# Altium schematic sheet MCU.SchDoc
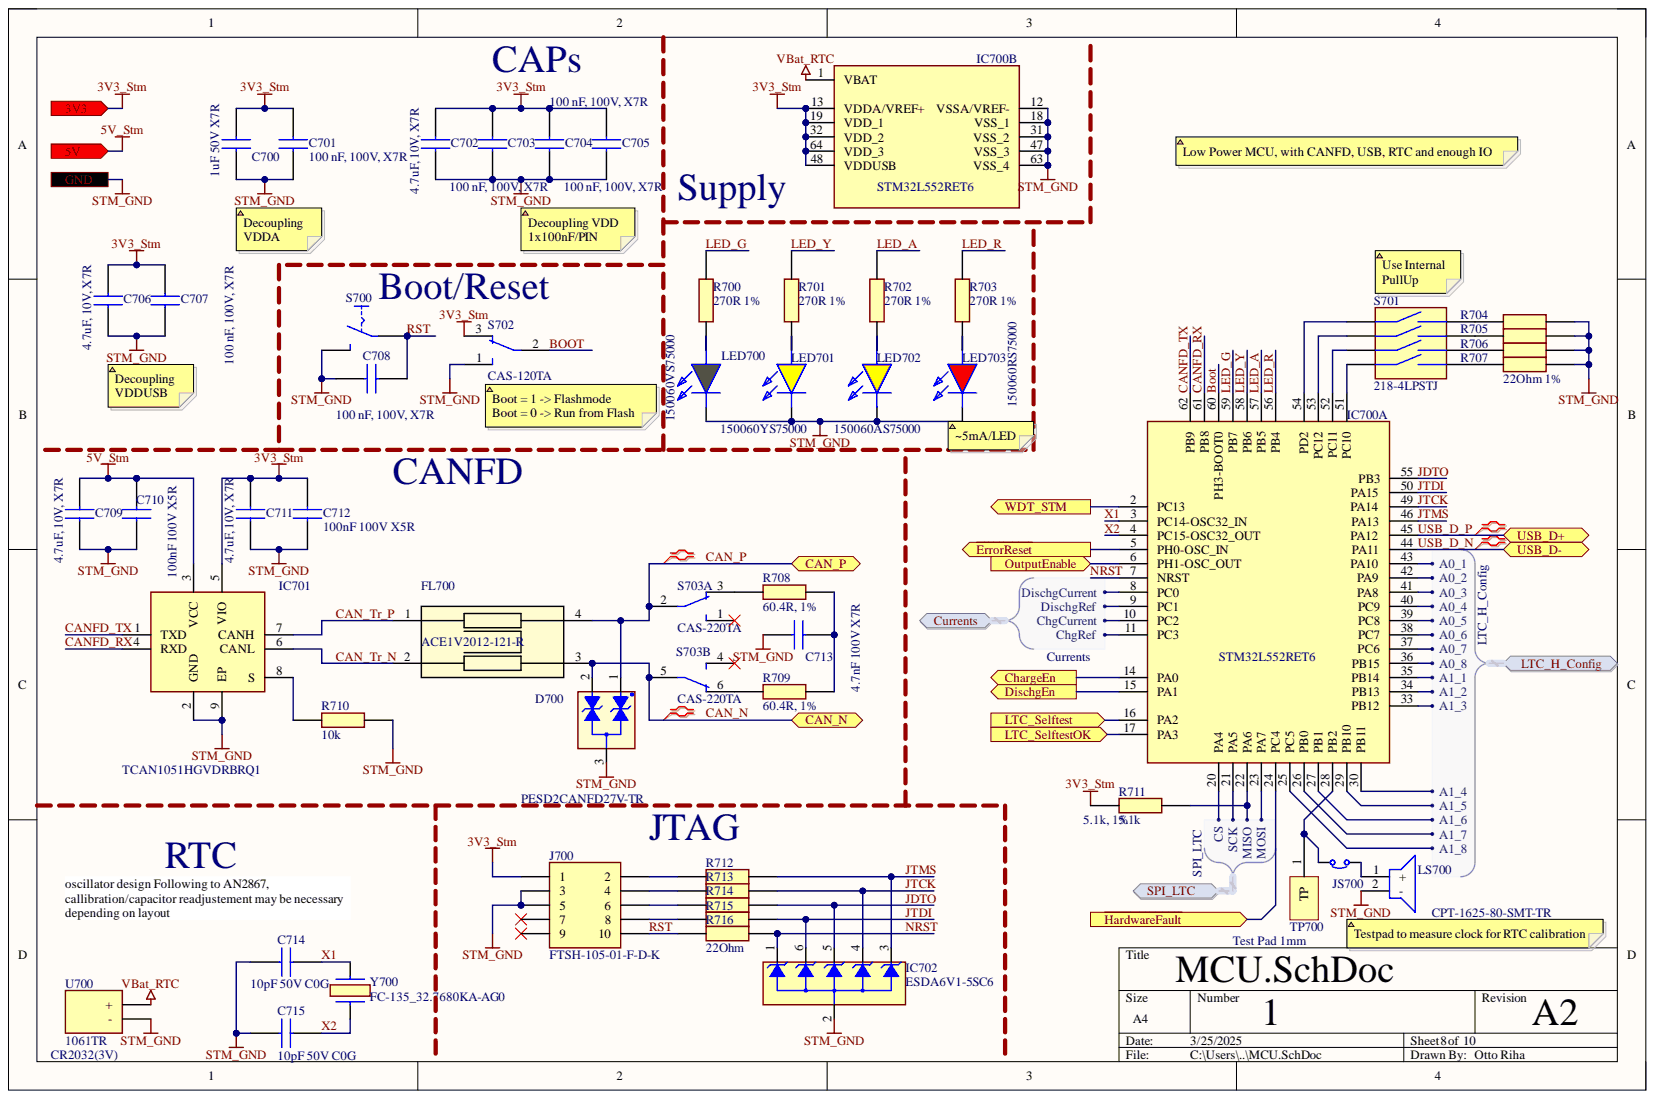
[diagram p0: MCU.SchDoc]

## Parts
C700  1uF 50V X7R  value 1uF  [CAPC1608X90N]
C701  100 nF, 100V, X7R  [MAJx16]
C702  4.7uF, 10V, X7R  [GRM18x]
C703  100 nF, 100V, X7R  [MAJx16]
C704  100 nF, 100V, X7R  [MAJx16]
C705  100 nF, 100V, X7R  [MAJx16]
C706  4.7uF, 10V, X7R  [GRM18x]
C707  100 nF, 100V, X7R  [MAJx16]
C708  100 nF, 100V, X7R  [MAJx16]
C709  4.7uF, 10V, X7R  [GRM18x]
C710  100nF 100V X5R  value 100nF  [CAPC1608X90N]
C711  4.7uF, 10V, X7R  [GRM18x]
C712  100nF 100V X5R  value 100nF  [CAPC1608X90N]
C713  4.7nF 100V X7R  value 4.7nF 100V  [CAPC1608X80N]
C714  10pF 50V C0G  [CAPC1608X90N]
C715  10pF 50V C0G  [CAPC1608X90N]
D700  PESD2CANFD27V-TR  [SOT95P230X110-3N]
FL700  ACE1V2012-121-R  [ACE1V2012121R]
IC700  STM32L552RET6  [QFP50P1200X1200X160-64N]  (parts A,B)
IC701  TCAN1051HGVDRBRQ1  [SON65P300X300X100-9N-D]
IC702  ESDA6V1-5SC6  [SOT95P280X145-6N]
J700  FTSH-105-01-F-D-K  [FTSH-105-XX-YYY-D-K]
JS700  SolderJumper  [SolderJumper]  (value not drawn)
LED700  150060VS75000  [LEDC1608X80N]
LED701  150060YS75000  [LEDC1608X80N]
LED702  150060AS75000  [LEDC1608X80N]
LED703  150060RS75000  [LEDC1608X80N]
LS700  CPT-1625-80-SMT-TR  [CPT162580SMTTR]
R700  270R 1%  value 270R  [RESC1608X55N]
R701  270R 1%  value 270R  [RESC1608X55N]
R702  270R 1%  value 270R  [RESC1608X55N]
R703  270R 1%  value 270R  [RESC1608X55N]
R704  value 22Ohm  [RESC1608X55N]  (hidden comment: 22Ohm 1%)
R705  value 22Ohm  [RESC1608X55N]  (hidden comment: 22Ohm 1%)
R706  22Ohm 1%  value 22Ohm  [RESC1608X55N]
R707  22Ohm 1%  [RESC1608X55N]
R708  60.4R, 1%  [RESC1608X55N]
R709  60.4R, 1%  [RESC1608X55N]
R710  value 10k  [RESC1608X50N]  (hidden comment: CRCW060310K0FKEI)
R711  5.1k, 1%  value 5.1k  [RESC1608X55N]
R712  value 22Ohm  [RESC1608X55N]  (hidden comment: 22Ohm 1%)
R713  value 22Ohm  [RESC1608X55N]  (hidden comment: 22Ohm 1%)
R714  value 22Ohm  [RESC1608X55N]  (hidden comment: 22Ohm 1%)
R715  22Ohm 1%  value 22Ohm  [RESC1608X55N]
R716  22Ohm 1%  value 22Ohm  [RESC1608X55N]
S700  MJTP1140A  [MJTP1140A]  (value not drawn)
S701  218-4LPSTJ  [2184LPSTJ]
S702  CAS-120TA  [CAS120TA]
S703  CAS-220TA  [CAS220TA]  (parts A,B)
TP700  Test Pad 1mm  [Test Pad 1mm-Footprint-1]
U700  1061TR  [1061TR]
Y700  FC-135_32.7680KA-AG0  [FC135327680KAAG0]

## Ports
3V3, 5V, CAN_N, CAN_P, ChargeEn, Currents, Currents.ChgCurrent, Currents.ChgRef, Currents.DischgCurrent, Currents.DischgRef, DischgEn, GND, LTC_H_Config, LTC_H_Config.A0_1, LTC_H_Config.A0_2, LTC_H_Config.A0_3, LTC_H_Config.A0_4, LTC_H_Config.A0_5, LTC_H_Config.A0_6, LTC_H_Config.A0_7, LTC_H_Config.A0_8, LTC_H_Config.A1_1, LTC_H_Config.A1_2, LTC_H_Config.A1_3, LTC_H_Config.A1_4, LTC_H_Config.A1_5, LTC_H_Config.A1_6, LTC_H_Config.A1_7, LTC_H_Config.A1_8, LTC_Selftest, LTC_SelftestOK, OutputEnable, SPI_LTC, SPI_LTC.CS, SPI_LTC.MISO, SPI_LTC.MOSI, SPI_LTC.SCK, USB_D+, USB_D-, WDT_STM, ~{ErrorReset}, ~{HardwareFault}

## Nets
VBat_RTC: IC700.1(VBAT) U700.1
3V3_Stm: C700.2 C701.2 C702.2 C703.2 C704.2 C705.2 C706.2 C707.2 C711.2 C712.2 IC700.13(VDDA/VREF+) IC700.19(VDD_1) IC700.32(VDD_2) IC700.48(VDDUSB) IC700.64(VDD_3) IC701.5(VIO) J700.1 R711.1 S702.3 ; port 3V3
5V_Stm: C709.2 C710.2 IC701.3(VCC) ; port 5V
Boot: IC700.60(PH3-BOOT0) S702.2
CAN_N: D700.2 FL700.3 S703.5 ; port CAN_N
CAN_P: D700.1 FL700.4 S703.2 ; port CAN_P
CAN_Tr_N: FL700.2 IC701.6(CANL)
CAN_Tr_P: FL700.1 IC701.7(CANH)
CANFD_RX: IC700.61(PB8) IC701.4(RXD)
CANFD_TX: IC700.62(PB9) IC701.1(TXD)
ChargeEn: IC700.14(PA0) ; port ChargeEn
DischgEn: IC700.15(PA1) ; port DischgEn
~{ErrorReset}: IC700.5(PH0-OSC_IN) ; port ~{ErrorReset}
~{HardwareFault}: IC700.24(PC4) ; port ~{HardwareFault}
JDTO: IC700.55(PB3) IC702.5 R714.2
JTCK: IC700.49(PA14) IC702.4 R713.2
JTDI: IC700.50(PA15) IC702.6 R715.2
JTMS: IC700.46(PA13) IC702.3 R712.2
LED_A: IC700.57(PB5) R702.2
LED_G: IC700.59(PB7) R700.2
LED_R: IC700.56(PB4) R703.2
LED_Y: IC700.58(PB6) R701.2
LTC_Selftest: IC700.16(PA2) ; port LTC_Selftest
LTC_SelftestOK: IC700.17(PA3) ; port LTC_SelftestOK
NRST: IC700.7(NRST) IC702.1 R716.2
OutputEnable: IC700.6(PH1-OSC_OUT) ; port OutputEnable
RST: C708.1 J700.10 R716.1 S700.1
STM_GND: C700.1 C701.1 C702.1 C703.1 C704.1 C705.1 C706.1 C707.1 C708.2 C709.1 C710.1 C711.1 C712.1 C713.1 C714.2 C715.2 D700.3 IC700.12(VSSA/VREF-) IC700.18(VSS_1) IC700.31(VSS_2) IC700.47(VSS_3) IC700.63(VSS_4) IC701.2(GND) IC701.9(EP) IC702.2 J700.3 J700.5 LED700.1 LED701.1 LED702.1 LED703.1 LS700.2 R704.1 R705.1 R706.1 R707.1 R710.1 S700.2 S702.1 U700.2 ; port GND
USB_D_N: IC700.44(PA11)
USB_D_P: IC700.45(PA12)
WDT_STM: IC700.2(PC13) ; port WDT_STM
X1: C714.1 IC700.3(PC14-OSC32_IN) Y700.1
X2: C715.1 IC700.4(PC15-OSC32_OUT) Y700.2
NetC713_2: C713.2 R708.2 R709.1
NetIC700_8: IC700.8(PC0) ; port Currents
NetIC700_9: IC700.9(PC1) ; port Currents
NetIC700_10: IC700.10(PC2) ; port Currents
NetIC700_11: IC700.11(PC3) ; port Currents
NetIC700_20: IC700.20(PA4) ; port SPI_LTC
NetIC700_21: IC700.21(PA5) ; port SPI_LTC
NetIC700_22: IC700.22(PA6) R711.2 ; port SPI_LTC
NetIC700_23: IC700.23(PA7) ; port SPI_LTC
NetIC700_25: IC700.25(PC5) ; port LTC_H_Config
NetIC700_26: IC700.26(PB0) ; port LTC_H_Config
NetIC700_27: IC700.27(PB1) ; port LTC_H_Config
NetIC700_28: IC700.28(PB2) JS700.1 TP700.1
NetIC700_29: IC700.29(PB10) ; port LTC_H_Config
NetIC700_30: IC700.30(PB11) ; port LTC_H_Config
NetIC700_33: IC700.33(PB12) ; port LTC_H_Config
NetIC700_34: IC700.34(PB13) ; port LTC_H_Config
NetIC700_35: IC700.35(PB14) ; port LTC_H_Config
NetIC700_36: IC700.36(PB15) ; port LTC_H_Config
NetIC700_37: IC700.37(PC6) ; port LTC_H_Config
NetIC700_38: IC700.38(PC7) ; port LTC_H_Config
NetIC700_39: IC700.39(PC8) ; port LTC_H_Config
NetIC700_40: IC700.40(PC9) ; port LTC_H_Config
NetIC700_41: IC700.41(PA8) ; port LTC_H_Config
NetIC700_42: IC700.42(PA9) ; port LTC_H_Config
NetIC700_43: IC700.43(PA10) ; port LTC_H_Config
NetIC700_51: IC700.51(PC10) S701.B4
NetIC700_52: IC700.52(PC11) S701.B3
NetIC700_53: IC700.53(PC12) S701.B2
NetIC700_54: IC700.54(PD2) S701.B1
NetIC701_8: IC701.8(S) R710.2
NetJ700_2: J700.2 R712.1
NetJ700_4: J700.4 R713.1
NetJ700_6: J700.6 R714.1
NetJ700_7: J700.7
NetJ700_8: J700.8 R715.1
NetJ700_9: J700.9
NetJS700_2: JS700.2 LS700.1
NetLED700_2: LED700.2 R700.1
NetLED701_2: LED701.2 R701.1
NetLED702_2: LED702.2 R702.1
NetLED703_2: LED703.2 R703.1
NetR704_2: R704.2 S701.A1
NetR705_2: R705.2 S701.A2
NetR706_2: R706.2 S701.A3
NetR707_2: R707.2 S701.A4
NetR708_1: R708.1 S703.3
NetR709_2: R709.2 S703.6
NetS703_1: S703.1
NetS703_4: S703.4

## Library data of the parts
C700 = 06035C105MAT2A: Capacitor 1uF 50V X7R; Manufacturer_Name: Kyocera AVX; Component Kind: Standard; Datasheet Link: https://componentsearchengine.com/Datasheets/1/06031A100FAT2A.pdf; Height: 0.9mm; Library Name: SamacSys.SchLib; Manufacturer_Part_Number: 06035C105MAT2A; Mouser Part Number: 581-06035C105MAT2A; Mouser Price/Stock: https://www.mouser.co.uk/ProductDetail/KYOCERA-AVX/06035C105MAT2A?qs=%252BdQmOuGyFcHMsevPtc6OZQ%3D%3D
C701 C703-C705 C707 C708 = MAJCH168BB7104KTEA01: Capacitor 100nF, 100V, X7R; Manufacturer_Name: TAIYO YUDEN; Arrow Part Number: MAJCH168BB7104KTEA01; Arrow Price/Stock: https://www.arrow.com/en/products/majch168bb7104ktea01/taiyo-yuden?utm_currency=USD&region=nac; Component Kind: Standard; Datasheet Link: https://ds.yuden.co.jp/TYCOMPAS/or/detail?pn=MAJCH168BB7104KTEA01; Height: 0.8mm; Library Name: SamacSys.SchLib; Manufacturer_Part_Number: MAJCH168BB7104KTEA01; Mouser Part Number: 963-MAJCH168BB7104KT; Mouser Price/Stock: https://www.mouser.co.uk/ProductDetail/TAIYO-YUDEN/MAJCH168BB7104KTEA01?qs=ZcfC38r4PovaTBrG0IdezQ%3D%3D; Supplier 1: Mouser; Supplier Part Number 1: 963-MAJCH168BB7104KT
C702 C706 C709 C711 = GRM188Z71A475KE15J: Capacitor  4.7uF, 10V, X7R; Manufacturer_Name: Murata Electronics; Component Kind: Standard; Datasheet Link: https://search.murata.co.jp/Ceramy/image/img/A01X/G101/ENG/GRM188Z71A475KE15-01A.pdf; Height: 0.9mm; Library Name: SamacSys.SchLib; Manufacturer_Part_Number: GRM188Z71A475KE15J; Mouser Part Number: 81-GRM188Z71A475KE5J; Mouser Price/Stock: https://www.mouser.co.uk/ProductDetail/Murata-Electronics/GRM188Z71A475KE15J?qs=Ey7%2FXF42M3fe52ZB0l7gLw%3D%3D; Supplier 1: Mouser; Supplier Part Number 1: 81-GRM188Z71A475KE5J
C710 C712 = HMK107BJ104KA-T: Capacitor 100nF X5R 100VDC; Manufacturer_Name: TAIYO YUDEN; Component Kind: Standard; Datasheet Link: https://ds.yuden.co.jp/TYCOMPAS/jp/specSheet?pn=MSASH168SB5104KTNA01; Height: 0.9mm; Library Name: SamacSys.SchLib; Manufacturer_Part_Number: HMK107BJ104KA-T; Mouser Part Number: 963-HMK107BJ104KA-T; Mouser Price/Stock: https://www.mouser.co.uk/ProductDetail/Taiyo-Yuden/HMK107BJ104KA-T?qs=I6KAKw0tg2wpEwZmo3gKZg%3D%3D
  C710: Value: 100nF
C713 = C1608X7R2A472K080AA: Capacitor 4.7nF 100V X7R; Manufacturer_Name: TDK; Arrow Part Number: C1608X7R2A472K080AA; Arrow Price/Stock: https://www.arrow.com/en/products/c1608x7r2a472k080aa/tdk?utm_currency=USD&region=nac; Component Kind: Standard; Datasheet Link: https://product.tdk.com/info/en/catalog/datasheets/mlcc_commercial_midvoltage_en.pdf; Height: 0.8mm; Library Name: SamacSys.SchLib; Manufacturer_Part_Number: C1608X7R2A472K080AA; Mouser Part Number: 810-C1608X7R2A472K; Mouser Price/Stock: https://www.mouser.co.uk/ProductDetail/TDK/C1608X7R2A472K080AA/?qs=NRhsANhppD8eiVaujK4TFA%3D%3D; Value: 4.7nF 100V
C714 C715 = 06035A100BAT2A: Capacitor 10pF 50V C0G; Manufacturer_Name: Kyocera AVX; Component Kind: Standard; Datasheet Link: https://componentsearchengine.com/Datasheets/1/06031A100FAT2A.pdf; Height: 0.9mm; Library Name: SamacSys.SchLib; Manufacturer_Part_Number: 06035A100BAT2A; Mouser Part Number: 581-06035A100BAT2A; Mouser Price/Stock: https://www.mouser.com/Search/Refine.aspx?Keyword=581-06035A100BAT2A
D700 = PESD2CANFD27V-TR: Diode; Manufacturer_Name: Nexperia; Arrow Part Number: PESD2CANFD27V-TR; Arrow Price/Stock: null?utm_currency=USD&region=nac; Component Kind: Standard; Datasheet Link: https://assets.nexperia.com/documents/data-sheet/PESD2CANFD27V-T.pdf; Height: 1.1mm; Library Name: SamacSys.SchLib; Manufacturer_Part_Number: PESD2CANFD27V-TR; Mouser Part Number: 771-PESD2CANFD27V-TR; Mouser Price/Stock: https://www.mouser.co.uk/ProductDetail/Nexperia/PESD2CANFD27V-TR?qs=OlC7AqGiEDlVVUGGaoaotA%3D%3D
FL700 = ACE1V2012-121-R: Filter; Manufacturer_Name: Eaton; Component Kind: Standard; Datasheet Link: https://www.eaton.com/content/dam/eaton/products/electronic-components/resources/data-sheet/eaton-ace1v2012-automotive-common-mode-chip-inductor-data-sheet.pdf; Height: 1.4mm; Library Name: SamacSys.SchLib; Manufacturer_Part_Number: ACE1V2012-121-R; Mouser Part Number: 504-ACE1V2012-121-R; Mouser Price/Stock: https://www.mouser.co.uk/ProductDetail/Coiltronics-Eaton/ACE1V2012-121-R?qs=YwPsRIUVAOfYW6FI0EMgkw%3D%3D
IC700 = STM32L552RET6: Integrated Circuit; Manufacturer_Name: STMicroelectronics; Arrow Part Number: STM32L552RET6; Arrow Price/Stock: https://www.arrow.com/en/products/stm32l552ret6/stmicroelectronics?region=nac; Component Kind: Standard; Datasheet Link: https://www.mouser.in/datasheet/2/389/dm00532748-1799512.pdf; Height: 1.6mm; Library Name: SamacSys.SchLib; Manufacturer_Part_Number: STM32L552RET6; Mouser Part Number: 511-STM32L552RET6; Mouser Price/Stock: https://www.mouser.co.uk/ProductDetail/STMicroelectronics/STM32L552RET6?qs=%252B6g0mu59x7I6Ku2G1CuBXA%3D%3D
IC701 = TCAN1051HGVDRBRQ1: Integrated Circuit; Manufacturer_Name: Texas Instruments; Arrow Part Number: TCAN1051HGVDRBRQ1; Arrow Price/Stock: https://www.arrow.com/en/products/tcan1051hgvdrbrq1/texas-instruments?region=nac; Component Kind: Standard; Datasheet Link: http://www.ti.com/lit/gpn/TCAN1051HGV-Q1; Height: 1mm; Library Name: SamacSys.SchLib; Manufacturer_Part_Number: TCAN1051HGVDRBRQ1; Mouser Part Number: 595-CAN1051HGVDRBRQ1; Mouser Price/Stock: https://www.mouser.co.uk/ProductDetail/Texas-Instruments/TCAN1051HGVDRBRQ1?qs=HXFqYaX1Q2xR%252Bfd0ySUCjA%3D%3D
IC702 = ESDA6V1-5SC6: Integrated Circuit; Manufacturer_Name: STMicroelectronics; Arrow Part Number: ESDA6V1-5SC6; Arrow Price/Stock: https://www.arrow.com/en/products/esda6v1-5sc6/stmicroelectronics?region=nac; Component Kind: Standard; Datasheet Link: http://www.st.com/st-web-ui/static/active/en/resource/technical/document/datasheet/CD00005102.pdf; Height: 1.45mm; Library Name: SamacSys.SchLib; Manufacturer_Part_Number: ESDA6V1-5SC6; Mouser Part Number: 511-ESDA6V1-5SC6; Mouser Price/Stock: https://www.mouser.co.uk/ProductDetail/STMicroelectronics/ESDA6V1-5SC6?qs=ZN9SVtODT3yxqNpCUKP6Bg%3D%3D
J700 = FTSH-105-01-F-D-K: Connector; Manufacturer_Name: SAMTEC; Arrow Part Number: FTSH-105-01-F-D-K; Arrow Price/Stock: https://www.arrow.com/en/products/ftsh-105-01-f-d-k/samtec?utm_currency=USD&region=europe; Component Kind: Standard; Datasheet Link: http://suddendocs.samtec.com/prints/ftsh-1xx-xx-xxx-d-xxx-xx-mkt.pdf; Library Name: SamacSys.SchLib; Manufacturer_Part_Number: FTSH-105-01-F-D-K; Mouser Part Number: 200-FTSH10501FDK; Mouser Price/Stock: https://www.mouser.co.uk/ProductDetail/Samtec/FTSH-105-01-F-D-K?qs=FESYatJ8odIwRE%252Bc%2FZcQYA%3D%3D
LED700 = 150060VS75000: LED; Manufacturer_Name: Wurth Elektronik; Component Kind: Standard; Datasheet Link: https://componentsearchengine.com/Datasheets/2/150060VS75000.pdf; Height: 0.8mm; Library Name: SamacSys.SchLib; Manufacturer_Part_Number: 150060VS75000; Mouser Part Number: 710-150060VS75000; Mouser Price/Stock: https://www.mouser.co.uk/ProductDetail/Wurth-Elektronik/150060VS75000?qs=LlUlMxKIyB1Q1Bi5mQ%2FKLw%3D%3D
LED701 = 150060YS75000: LED; Manufacturer_Name: Wurth Elektronik; Component Kind: Standard; Datasheet Link: http://uk.rs-online.com/web/p/products/8154219; Height: 0.8mm; Library Name: SamacSys.SchLib; Manufacturer_Part_Number: 150060YS75000; Mouser Part Number: 710-150060YS75000; Mouser Price/Stock: https://www.mouser.co.uk/ProductDetail/Wurth-Elektronik/150060YS75000?qs=LlUlMxKIyB0nKmwefHgtZw%3D%3D
LED702 = 150060AS75000: LED; Manufacturer_Name: Wurth Elektronik; Component Kind: Standard; Datasheet Link: https://componentsearchengine.com/Datasheets/2/150060AS75000.pdf; Height: 0.8mm; Library Name: SamacSys.SchLib; Manufacturer_Part_Number: 150060AS75000; Mouser Part Number: 710-150060AS75000; Mouser Price/Stock: https://www.mouser.co.uk/ProductDetail/Wurth-Elektronik/150060AS75000?qs=d0WKAl%252BL4KbvMBYryeof0Q%3D%3D
LED703 = 150060RS75000: LED; Manufacturer_Name: Wurth Elektronik; Component Kind: Standard; Datasheet Link: http://katalog.we-online.de/led/datasheet/150060RS75000.pdf; Height: 0.8mm; Library Name: SamacSys.SchLib; Manufacturer_Part_Number: 150060RS75000; Mouser Part Number: 710-150060RS75000; Mouser Price/Stock: https://www.mouser.co.uk/ProductDetail/Wurth-Elektronik/150060RS75000?qs=LlUlMxKIyB3QnmZ3fw%2FVCA%3D%3D
LS700 = CPT-1625-80-SMT-TR: Loudspeaker or Buzzer; Manufacturer_Name: Same Sky; Component Kind: Standard; Datasheet Link: https://www.sameskydevices.com/product/resource/supplyframepdf/cpt-1625-80-smt-tr.pdf; Height: 3mm; Manufacturer_Part_Number: CPT-1625-80-SMT-TR; Mouser Part Number: 490-CPT-1625-80SMTTR; Mouser Price/Stock: https://www.mouser.co.uk/ProductDetail/CUI-Devices/CPT-1625-80-SMT-TR?qs=P1JMDcb91o43eCFIymFs3Q%3D%3D
R700-R703 = ERJ-3EKF2700V: Resistor 270R 1%; Manufacturer_Name: Panasonic; Arrow Part Number: ERJ-3EKF2700V; Arrow Price/Stock: https://www.arrow.com/en/products/erj-3ekf2700v/panasonic?region=nac; Component Kind: Standard; Datasheet Link: http://industrial.panasonic.com/cdbs/www-data/pdf/RDA0000/AOA0000C86.pdf; Height: 0.55mm; Library Name: SamacSys.SchLib; Manufacturer_Part_Number: ERJ-3EKF2700V; Mouser Part Number: 667-ERJ-3EKF2700V; Mouser Price/Stock: https://www.mouser.co.uk/ProductDetail/Panasonic/ERJ-3EKF2700V?qs=iBwFsHVUAOdaUVxng5ojCw%3D%3D
R704-R707 R712-R716 = RC0603FR-0722RL: Resistor 22Ohm 1%; Manufacturer_Name: YAGEO; Arrow Part Number: RC0603FR-0722RL; Arrow Price/Stock: https://www.arrow.com/en/products/rc0603fr-0722rl/yageo?region=nac; Component Kind: Standard; Datasheet Link: http://www.farnell.com/datasheets/1868787.pdf; Height: 0.55mm; Library Name: SamacSys.SchLib; Manufacturer_Part_Number: RC0603FR-0722RL; Mouser Part Number: 603-RC0603FR-0722RL; Mouser Price/Stock: https://www.mouser.co.uk/ProductDetail/YAGEO/RC0603FR-0722RL?qs=diQw95jMAeMOsxb8bne7fg%3D%3D; Supplier 1: Future Electronics; Supplier Part Number 1: 8636706
  R704: Value: 22Ohm
  R707: Value: 22Ohm 1%
R708 R709 = CPF0603F60R4C1: Resistor 60.4R, 1%; Manufacturer_Name: TE Connectivity; Component Kind: Standard; Datasheet Link: https://www.te.com/commerce/DocumentDelivery/DDEController?Action=srchrtrv&DocNm=8-1773459-4_ELEVATOR_ESCALATOR_SOLUTIONS&DocType=Data%20Sheet&DocLang=English&PartCntxt=4-1879335-4&DocFormat=pdf; Height: 0.55mm; Library Name: SamacSys.SchLib; Manufacturer_Part_Number: CPF0603F60R4C1; Supplier 1: Mouser; Supplier Part Number 1: 279-CPF0603F60R4C1; Value: 60.4R
R710 = CRCW060310K0FKEI: Resistor 10k, 1%; Manufacturer_Name: Vishay; Arrow Part Number: CRCW060310K0FKEI; Arrow Price/Stock: https://www.arrow.com/en/products/crcw060310k0fkei/vishay?utm_currency=USD&region=nac; Component Kind: Standard; Datasheet Link: http://www.vishay.com/docs/20035/dcrcwe3.pdf; Height: 0.5mm; Library Name: SamacSys.SchLib; Manufacturer_Part_Number: CRCW060310K0FKEI; Mouser Part Number: 71-CRCW060310K0FKEI; Mouser Price/Stock: https://www.mouser.co.uk/ProductDetail/Vishay-Dale/CRCW060310K0FKEI?qs=3qWEzBg5KnKofE%252B8acPBRA%3D%3D; Supplier 1: Mouser; Supplier Part Number 1: 71-CRCW060310K0FKEI
R711 = CRCW06035K10FKEBC: Resistor 5.1k, 1%; Manufacturer_Name: Vishay; Arrow Part Number: CRCW06035K10FKEBC; Arrow Price/Stock: https://www.arrow.com/en/products/crcw06035k10fkebc/vishay?region=nac; Component Kind: Standard; Datasheet Link: https://www.vishay.com/docs/28773/crcwce3.pdf; Height: 0.55mm; Library Name: SamacSys.SchLib; Manufacturer_Part_Number: CRCW06035K10FKEBC; Mouser Part Number: 71-CRCW06035K10FKEBC; Mouser Price/Stock: https://www.mouser.co.uk/ProductDetail/Vishay-Dale/CRCW06035K10FKEBC?qs=wd5RIQLrsJiajonkePuzqw%3D%3D; Supplier 1: Mouser; Supplier Part Number 1: 71-CRCW06035K10FKEBC
S700 = MJTP1140A: Switch; Manufacturer_Name: Apem; Component Kind: Standard; Datasheet Link: https://componentsearchengine.com/Datasheets/1/MJTP1140A.pdf; Height: 1.5mm; Library Name: SamacSys.SchLib; Manufacturer_Part_Number: MJTP1140A; Mouser Part Number: 642-MJTP1140A; Mouser Price/Stock: https://www.mouser.com/Search/Refine.aspx?Keyword=642-MJTP1140A
S701 = 218-4LPSTJ: Switch; Manufacturer_Name: CTS; Arrow Part Number: 218-4LPSTJ; Arrow Price/Stock: https://www.arrow.com/en/products/218-4lpstj/cts; Component Kind: Standard; Datasheet Link: https://www.ctscorp.com/wp-content/uploads/218.pdf; Height: 2.7mm; Library Name: SamacSys.SchLib; Manufacturer_Part_Number: 218-4LPSTJ; Mouser Part Number: 774-218-4LPSTJ; Mouser Price/Stock: https://www.mouser.co.uk/ProductDetail/CTS-Electronic-Components/218-4LPSTJ?qs=qNzHFtQhdJ%2Frpuf7cnHHWA%3D%3D
S702 = CAS-120TA: Switch; Manufacturer_Name: Nidec Copal; Arrow Part Number: CAS-120TA; Arrow Price/Stock: https://www.arrow.com/en/products/cas-120ta/nidec-copal-electronics; Component Kind: Standard; Datasheet Link: https://www.mouser.com/datasheet/2/972/cas-1628136.pdf; Height: 2.5mm; Library Name: SamacSys.SchLib; Manufacturer_Part_Number: CAS-120TA; Mouser Part Number: 229-CAS-120TA; Mouser Price/Stock: https://www.mouser.co.uk/ProductDetail/Nidec-Components/CAS-120TA?qs=XeJtXLiO41SaUq1d6RzxrQ%3D%3D
S703 = CAS-220TA: Switch; Manufacturer_Name: Nidec Copal; Component Kind: Standard; Datasheet Link: https://www.digikey.ph/en/products/detail/nidec-components-corporation/CAS-220TA/341663?msockid=39f1ec84d77b6f4428b7f904d6676eed; Height: 2.5mm; Library Name: SamacSys.SchLib; Manufacturer_Part_Number: CAS-220TA; Mouser Part Number: 229-CAS-220TA; Mouser Price/Stock: https://www.mouser.co.uk/ProductDetail/Nidec-Components/CAS-220TA?qs=XeJtXLiO41Tq8gHkPWHRfA%3D%3D
TP700 = CMP-002-00031-3: 1mm radius circuilar test pad; Component Kind: Standard; Library Name: EPFL Racing Team
U700 = 1061TR: Undefined or Miscellaneous; Manufacturer_Name: Keystone Electronics; Arrow Part Number: 1061TR; Arrow Price/Stock: https://www.arrow.com/en/products/1061tr/keystone-electronics?utm_currency=USD&region=europe; Component Kind: Standard; Datasheet Link: https://www.keyelco.com/product-pdf.cfm?p=3845; Height: 7.62mm; Library Name: SamacSys.SchLib; Manufacturer_Part_Number: 1061TR; Mouser Part Number: 534-1061TR; Mouser Price/Stock: https://www.mouser.co.uk/ProductDetail/Keystone-Electronics/1061TR?qs=q0tsjPZWdm8mEkIEudXsxA%3D%3D
Y700 = FC-135_32.7680KA-AG0: Crystal or Oscillator; Manufacturer_Name: Epson Timing; Manufacturer_Part_Number: FC-135 32.7680KA-AG0; Arrow Part Number: FC-135 32.7680KA-AG0; Arrow Price/Stock: https://www.arrow.com/en/products/fc-13532.7680ka-ag0/epson-electronics-america?utm_currency=USD&region=nac; Component Kind: Standard; Datasheet Link: https://support.epson.biz/td/api/doc_check.php?dl=brief_FC-135&lang=en/.pdf; Height: 0.9mm; Library Name: SamacSys.SchLib; Mouser Part Number: 732-FC135-32.76KAAG0; Mouser Price/Stock: https://www.mouser.co.uk/ProductDetail/Epson-Timing/FC-135-32.7680KA-AG0?qs=l3LL3yk8ONZCQSM4diwOUg%3D%3D
JS700 = SolderJumper: Component Kind: Standard; Library Name: SamacSys.SchLib
